# Altium schematic sheet FPGA_MGT.SchDoc
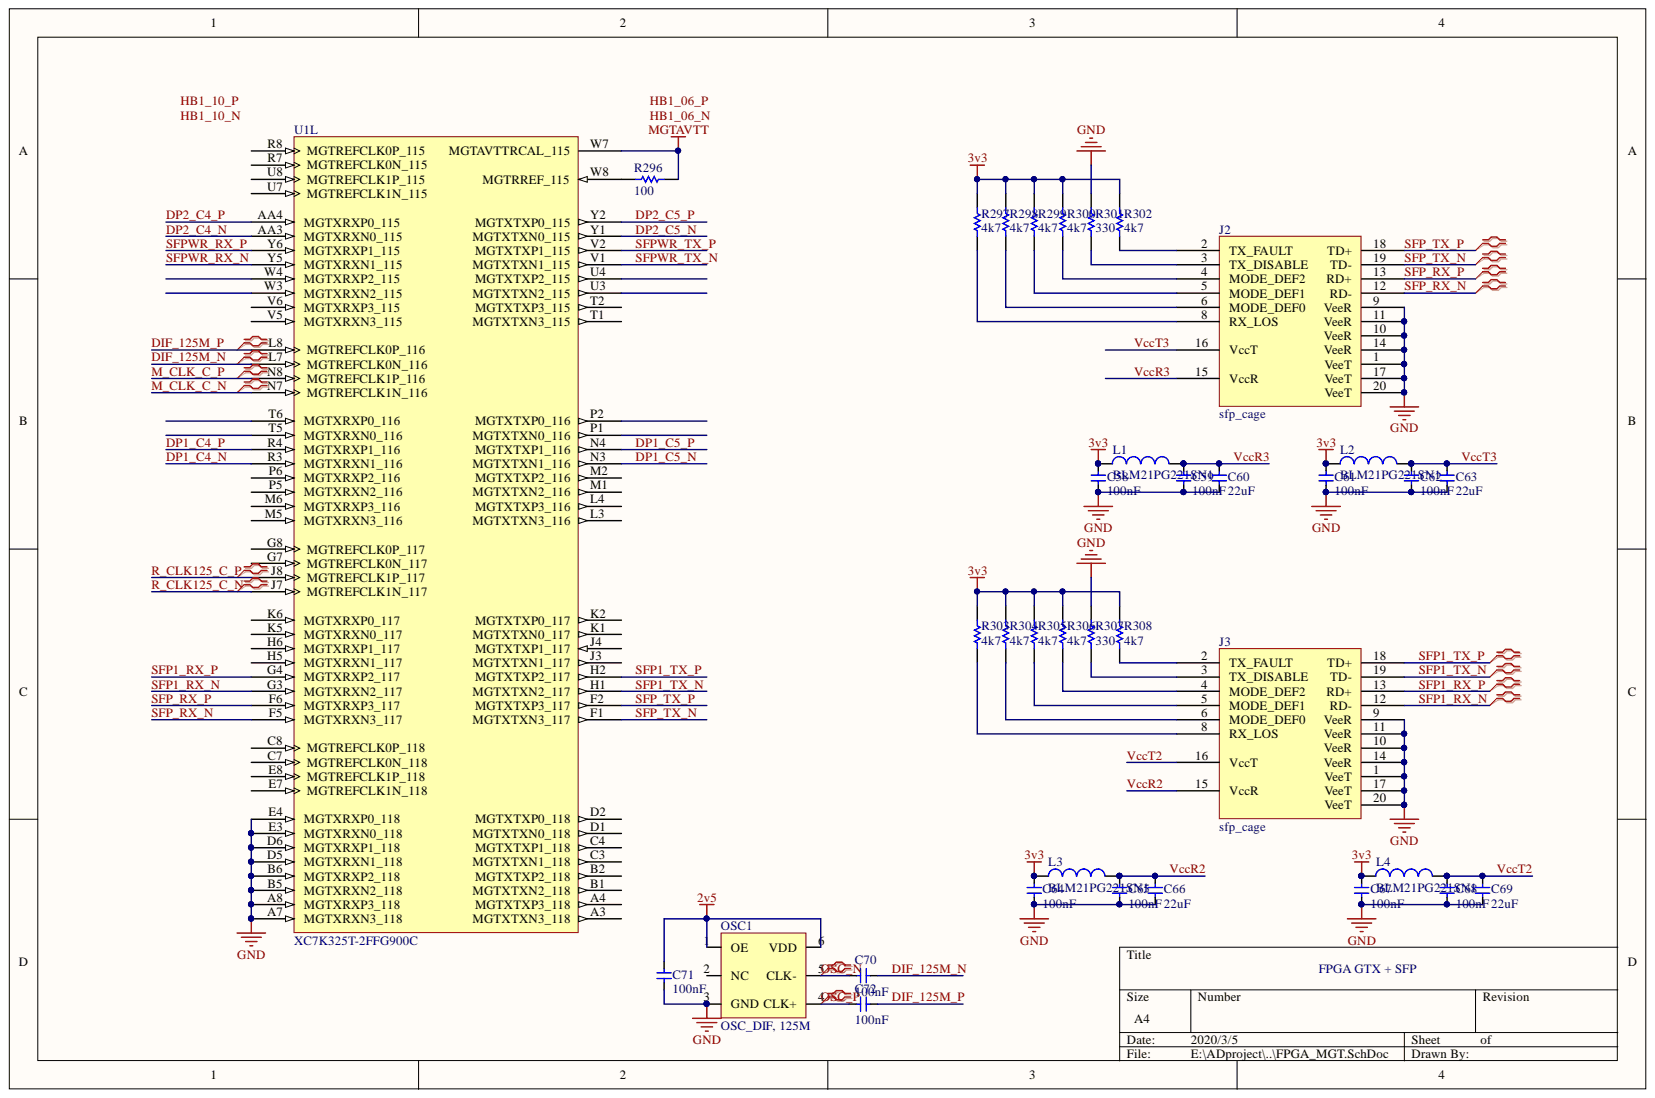
[diagram p0: FPGA_MGT.SchDoc]

## Parts
C58  value 100nF  [0402]
C59  value 100nF  [0402]
C60  value 22uF  [0603]
C61  value 100nF  [0402]
C62  value 100nF  [0402]
C63  value 22uF  [0603]
C64  value 100nF  [0402]
C65  value 100nF  [0402]
C66  value 22uF  [0603]
C67  value 100nF  [0402]
C68  value 100nF  [0402]
C69  value 22uF  [0603]
C70  value 100nF  [0402]
C71  value 100nF  [0402]
C72  value 100nF  [0402]
J2  sfp_cage  [SFP_cage]
J3  sfp_cage  [SFP_cage]
L1  BLM21PG221SN1  [L0805Y]
L2  BLM21PG221SN1  [L0805Y]
L3  BLM21PG221SN1  [L0805Y]
L4  BLM21PG221SN1  [L0805Y]
OSC1  OSC_DIF, 125M  [3.2X5mm]
R296  value 100  [0402]
R297  value 4k7  [RESC0603(1608)_N]
R298  value 4k7  [RESC0603(1608)_N]
R299  value 4k7  [RESC0603(1608)_N]
R300  value 4k7  [RESC0603(1608)_N]
R301  value 330  [RESC0603(1608)_N]
R302  value 4k7  [RESC0603(1608)_N]
R303  value 4k7  [RESC0603(1608)_N]
R304  value 4k7  [RESC0603(1608)_N]
R305  value 4k7  [RESC0603(1608)_N]
R306  value 4k7  [RESC0603(1608)_N]
R307  value 330  [RESC0603(1608)_N]
R308  value 4k7  [RESC0603(1608)_N]
U1  XC7K325T-2FFG900C  [FFG900]  (part L)

## Nets
2v5: C71.1 OSC1.1(OE) OSC1.6(VDD)
3v3: C58.2 C61.2 C64.2 C67.2 L1.1 L2.1 L3.1 L4.1 R297.2 R298.2 R299.2 R300.2 R302.2 R303.2 R304.2 R305.2 R306.2 R308.2
GND: C58.1 C59.1 C60.1 C61.1 C62.1 C63.1 C64.1 C65.1 C66.1 C67.1 C68.1 C69.1 C71.2 J2.1(VeeT) J2.9(VeeR) J2.10(VeeR) J2.11(VeeR) J2.14(VeeR) J2.17(VeeT) J2.20(VeeT) J2.42 J3.1(VeeT) J3.9(VeeR) J3.10(VeeR) J3.11(VeeR) J3.14(VeeR) J3.17(VeeT) J3.20(VeeT) J3.42 OSC1.3(GND) R301.2 R307.2 U1.A7(MGTXRXN3_118) U1.A8(MGTXRXP3_118) U1.B5(MGTXRXN2_118) U1.B6(MGTXRXP2_118) U1.D5(MGTXRXN1_118) U1.D6(MGTXRXP1_118) U1.E3(MGTXRXN0_118) U1.E4(MGTXRXP0_118)
MGTAVTT: R296.1 U1.W7(MGTAVTTRCAL_115)
DIF_125M_N: C70.1 U1.L7(MGTREFCLK0N_116)
DIF_125M_P: C72.1 U1.L8(MGTREFCLK0P_116)
DP1_C4_N: U1.R3(MGTXRXN1_116)
DP1_C4_P: U1.R4(MGTXRXP1_116)
DP1_C5_N: U1.N3(MGTXTXN1_116)
DP1_C5_P: U1.N4(MGTXTXP1_116)
DP2_C4_N: U1.AA3(MGTXRXN0_115)
DP2_C4_P: U1.AA4(MGTXRXP0_115)
DP2_C5_N: U1.Y1(MGTXTXN0_115)
DP2_C5_P: U1.Y2(MGTXTXP0_115)
M_CLK_C_N: U1.N7(MGTREFCLK1N_116)
M_CLK_C_P: U1.N8(MGTREFCLK1P_116)
OSC_N: C70.2 OSC1.5(CLK-)
OSC_P: C72.2 OSC1.4(CLK+)
R_CLK125_C_N: U1.J7(MGTREFCLK1N_117)
R_CLK125_C_P: U1.J8(MGTREFCLK1P_117)
SFP1_RX_N: J3.12(RD-) U1.G3(MGTXRXN2_117)
SFP1_RX_P: J3.13(RD+) U1.G4(MGTXRXP2_117)
SFP1_TX_N: J3.19(TD-) U1.H1(MGTXTXN2_117)
SFP1_TX_P: J3.18(TD+) U1.H2(MGTXTXP2_117)
SFP_RX_N: J2.12(RD-) U1.F5(MGTXRXN3_117)
SFP_RX_P: J2.13(RD+) U1.F6(MGTXRXP3_117)
SFP_TX_N: J2.19(TD-) U1.F1(MGTXTXN3_117)
SFP_TX_P: J2.18(TD+) U1.F2(MGTXTXP3_117)
SFPWR_RX_N: U1.Y5(MGTXRXN1_115)
SFPWR_RX_P: U1.Y6(MGTXRXP1_115)
SFPWR_TX_N: U1.V1(MGTXTXN1_115)
SFPWR_TX_P: U1.V2(MGTXTXP1_115)
VccR2: C65.2 C66.2 J3.15(VccR) L3.2
VccR3: C59.2 C60.2 J2.15(VccR) L1.2
VccT2: C68.2 C69.2 J3.16(VccT) L4.2
VccT3: C62.2 C63.2 J2.16(VccT) L2.2
NetJ2_2: J2.2(TX_FAULT) R302.1
NetJ2_3: J2.3(TX_DISABLE) R301.1
NetJ2_4: J2.4(MODE_DEF2) R300.1
NetJ2_5: J2.5(MODE_DEF1) R299.1
NetJ2_6: J2.6(MODE_DEF0) R298.1
NetJ2_8: J2.8(RX_LOS) R297.1
NetJ3_2: J3.2(TX_FAULT) R308.1
NetJ3_3: J3.3(TX_DISABLE) R307.1
NetJ3_4: J3.4(MODE_DEF2) R306.1
NetJ3_5: J3.5(MODE_DEF1) R305.1
NetJ3_6: J3.6(MODE_DEF0) R304.1
NetJ3_8: J3.8(RX_LOS) R303.1
NetR296_2: R296.2 U1.W8(MGTRREF_115)
HB1_06_N:
HB1_06_P:
HB1_10_N:
HB1_10_P:

Not connected: OSC1 2(NC); U1 A3(MGTXTXN3_118) A4(MGTXTXP3_118) B1(MGTXTXN2_118) B2(MGTXTXP2_118) C3(MGTXTXN1_118) C4(MGTXTXP1_118) C7(MGTREFCLK0N_118) C8(MGTREFCLK0P_118) D1(MGTXTXN0_118) D2(MGTXTXP0_118) E7(MGTREFCLK1N_118) E8(MGTREFCLK1P_118) G7(MGTREFCLK0N_117) G8(MGTREFCLK0P_117) H5(MGTXRXN1_117) H6(MGTXRXP1_117) J3(MGTXTXN1_117) J4(MGTXTXP1_117) K1(MGTXTXN0_117) K2(MGTXTXP0_117) K5(MGTXRXN0_117) K6(MGTXRXP0_117) L3(MGTXTXN3_116) L4(MGTXTXP3_116) M1(MGTXTXN2_116) M2(MGTXTXP2_116) M5(MGTXRXN3_116) M6(MGTXRXP3_116) P1(MGTXTXN0_116) P2(MGTXTXP0_116) P5(MGTXRXN2_116) P6(MGTXRXP2_116) R7(MGTREFCLK0N_115) R8(MGTREFCLK0P_115) T1(MGTXTXN3_115) T2(MGTXTXP3_115) T5(MGTXRXN0_116) T6(MGTXRXP0_116) U3(MGTXTXN2_115) U4(MGTXTXP2_115) U7(MGTREFCLK1N_115) U8(MGTREFCLK1P_115) V5(MGTXRXN3_115) V6(MGTXRXP3_115) W3(MGTXRXN2_115) W4(MGTXRXP2_115)

## Library data of the parts
C58-C72 = CapSMT: Capacitor (Surface Mount); Component Kind: Standard; Signal Integrity: Cap; Simulation: CAP; Library Name: LS1_full_size.SCHLIB; Publisher: Altium Limited
L1-L4 = Inductor: Code_IEC: 1005; Value: 10mH; Code_JEITA: 0402; Component Kind: Standard; Description: Inductor; LatestRevisionDate: 17-Jul-2002; LatestRevisionNote: Re-released for DXP Platform.; Library Name: Mini_WR_core.SCHLIB; PackageReference: 0402-A; Published: 8-Jun-2000; Publisher: Altium Limited
OSC1 = OSC_DIF: Component Kind: Standard; Library Name: general.SchLib
R296 = CMP-1012-00454-1: Power: 0.1 W; Tolerance: 1 %; Component Kind: Standard; Library Name: Altium Content Vault; Technology: SMT
R297-R308 = CMP-1012-00454-1: Case-EIA: 0603; Case-Metric: 1608; Power: 0.1 W; Tolerance: 1 %; Component Kind: Standard; Library Name: Altium Content Vault; Technology: SMT
U1 = CMP-0361-00090-2: Kintex-7 FPGA, 500 User I/Os, 16 GTX, 900-Ball BGA, Speed Grade 2, Commerical Grade, Pb-Free; Manufacturer: Xilinx; GTX: 16; SelectIO HP: 150; SelectIO HR: 350; Speed Grade: -2; Code_JEDEC: MS-034-AAN-1; Component Kind: Standard; ComponentLink1Description: Datasheet; ComponentLink1URL: http://www.xilinx.com/support/documentation/data_sheets/ds180_7Series_Overview.pdf; ComponentLink2Description: Package Specification; ComponentLink2URL: http://www.xilinx.com/support/documentation/user_guides/ug865-Zynq-7000-Pkg-Pinout.pdf; DatasheetVersion: v1.12; Generic Part Number: XC7K325T; Library Name: Altium Content Vault; Mounting Technology: Surface Mount; PackageDescription: Flip-Chip BGA, Body 31 x 31 mm, 1.0 mm Pitch; PackageReference: FFG900; PackageVersion: Rev 1.1; PartId: 1; PartNumber: XC7K325T-2FFG900C; RoHS: Pb-Free
J2 J3 = sfp_cage: Component Kind: Standard; Library Name: general.SchLib
